# Altium schematic sheet PWM.SchDoc(PWM)
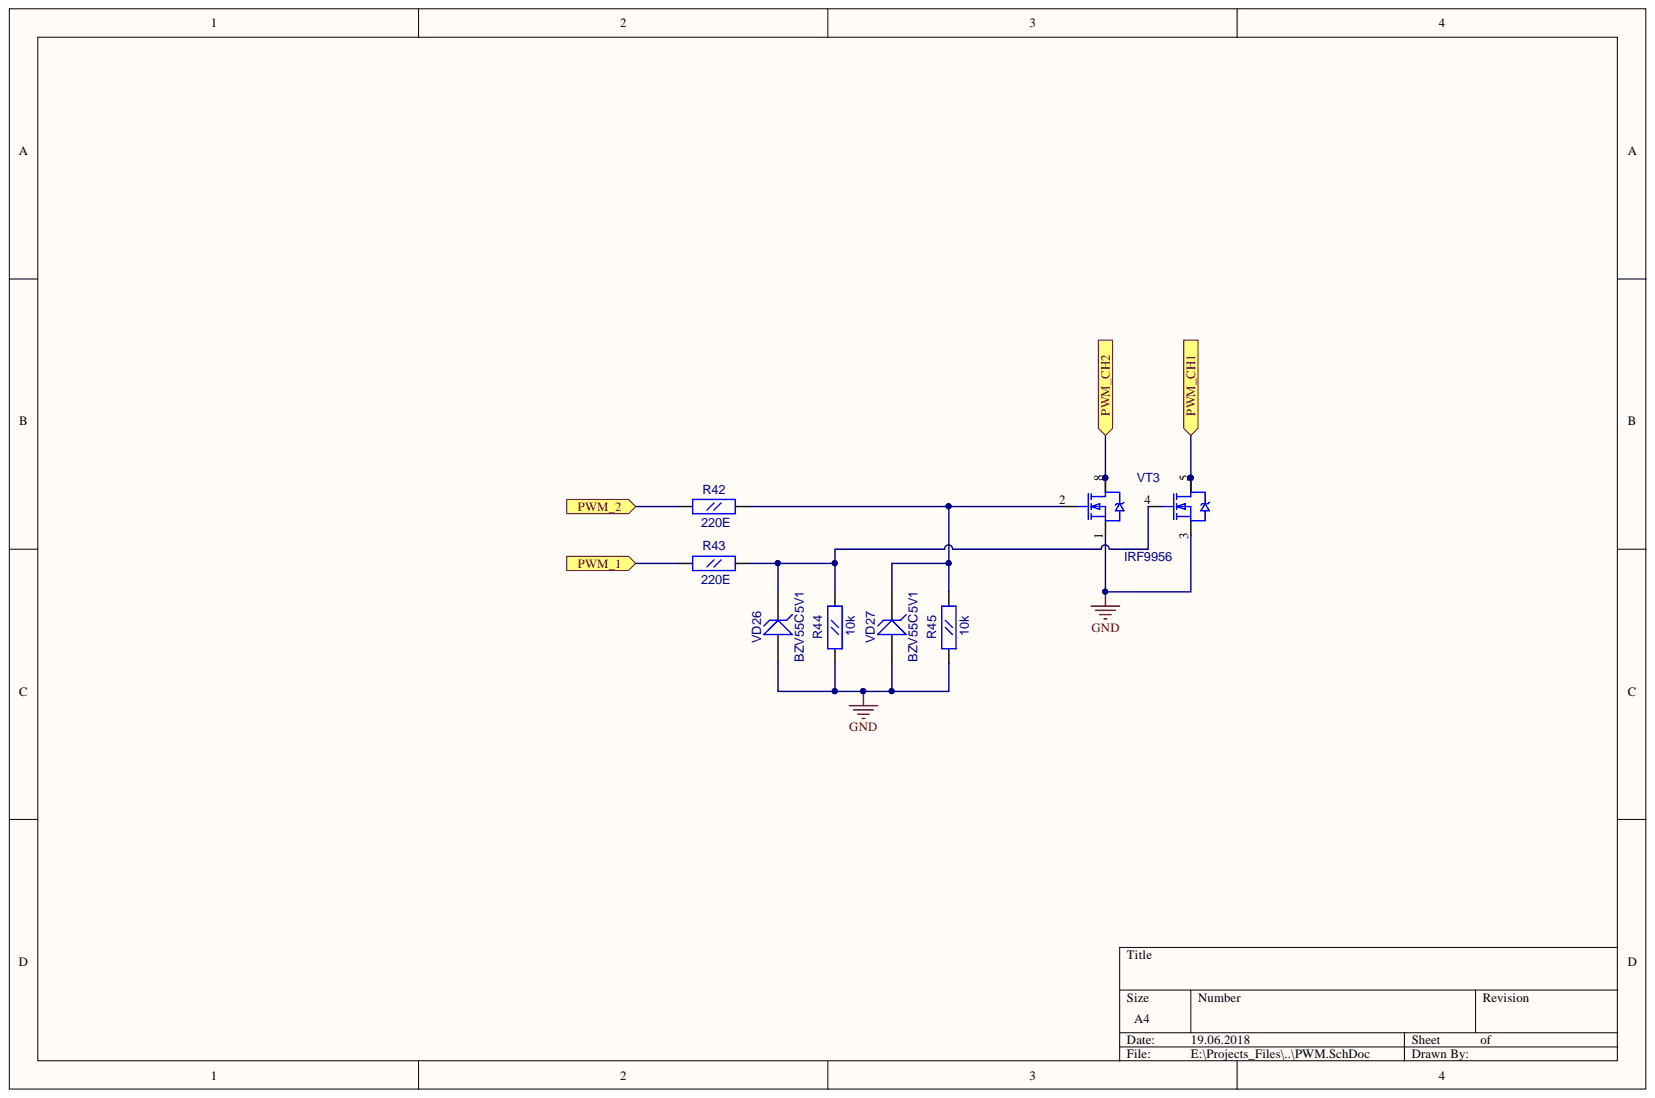
[diagram p0: PWM.SchDoc]

## Parts
R42  220E  [RC0603]
R43  220E  [RC0603]
R44  10k  [RC0603]
R45  10k  [RC0603]
VD26  BZV55C5V1  [SOD-80]
VD27  BZV55C5V1  [SOD-80]
VT3  IRF9956  [SO-8]

## Ports
PWM_1, PWM_2, PWM_CH1, PWM_CH2

## Nets
GND: R44.1 R45.1 VD26.1 VD27.1 VT3.1 VT3.3
PWM_1: R43.1 ; port PWM_1
PWM_2: R42.1 ; port PWM_2
PWM_CH1: VT3.5 VT3.6 ; port PWM_CH1
PWM_CH2: VT3.7 VT3.8 ; port PWM_CH2
NetR42_2: R42.2 R45.2 VD27.2 VT3.2
NetR43_2: R43.2 R44.2 VD26.2 VT3.4

## Library data of the parts
R42-R45 = RC0603: Description: RC0603; Component Kind: Standard; Library Name: gprs_modem_2.SchLib
  R42 R43: Value: 220E
  R44 R45: Value: 10k
VD26 VD27 = BZV55C5V1: Zener Voltage Regulator Diode; OriginalName: BZX84C10LT1; ComponentField7: Published 29-Sep-2000; ComponentField6: Pin 2 is not connected and not drawn.; Value: BZV55C5V1; Simulation: BZX84C10LT1; Component Kind: Standard; Library Name: gprs_modem_2.SchLib
VT3 = IRF9956: HEXFET Power MOSFET; OriginalName: IRLML2402; ComponentField7: Published 8-Jun-2000; Value: IRF9956; Component Kind: Standard; Library Name: gprs_modem_2.SchLib
